# Altium schematic sheet 05_POWER.SchDoc(05_POWER)
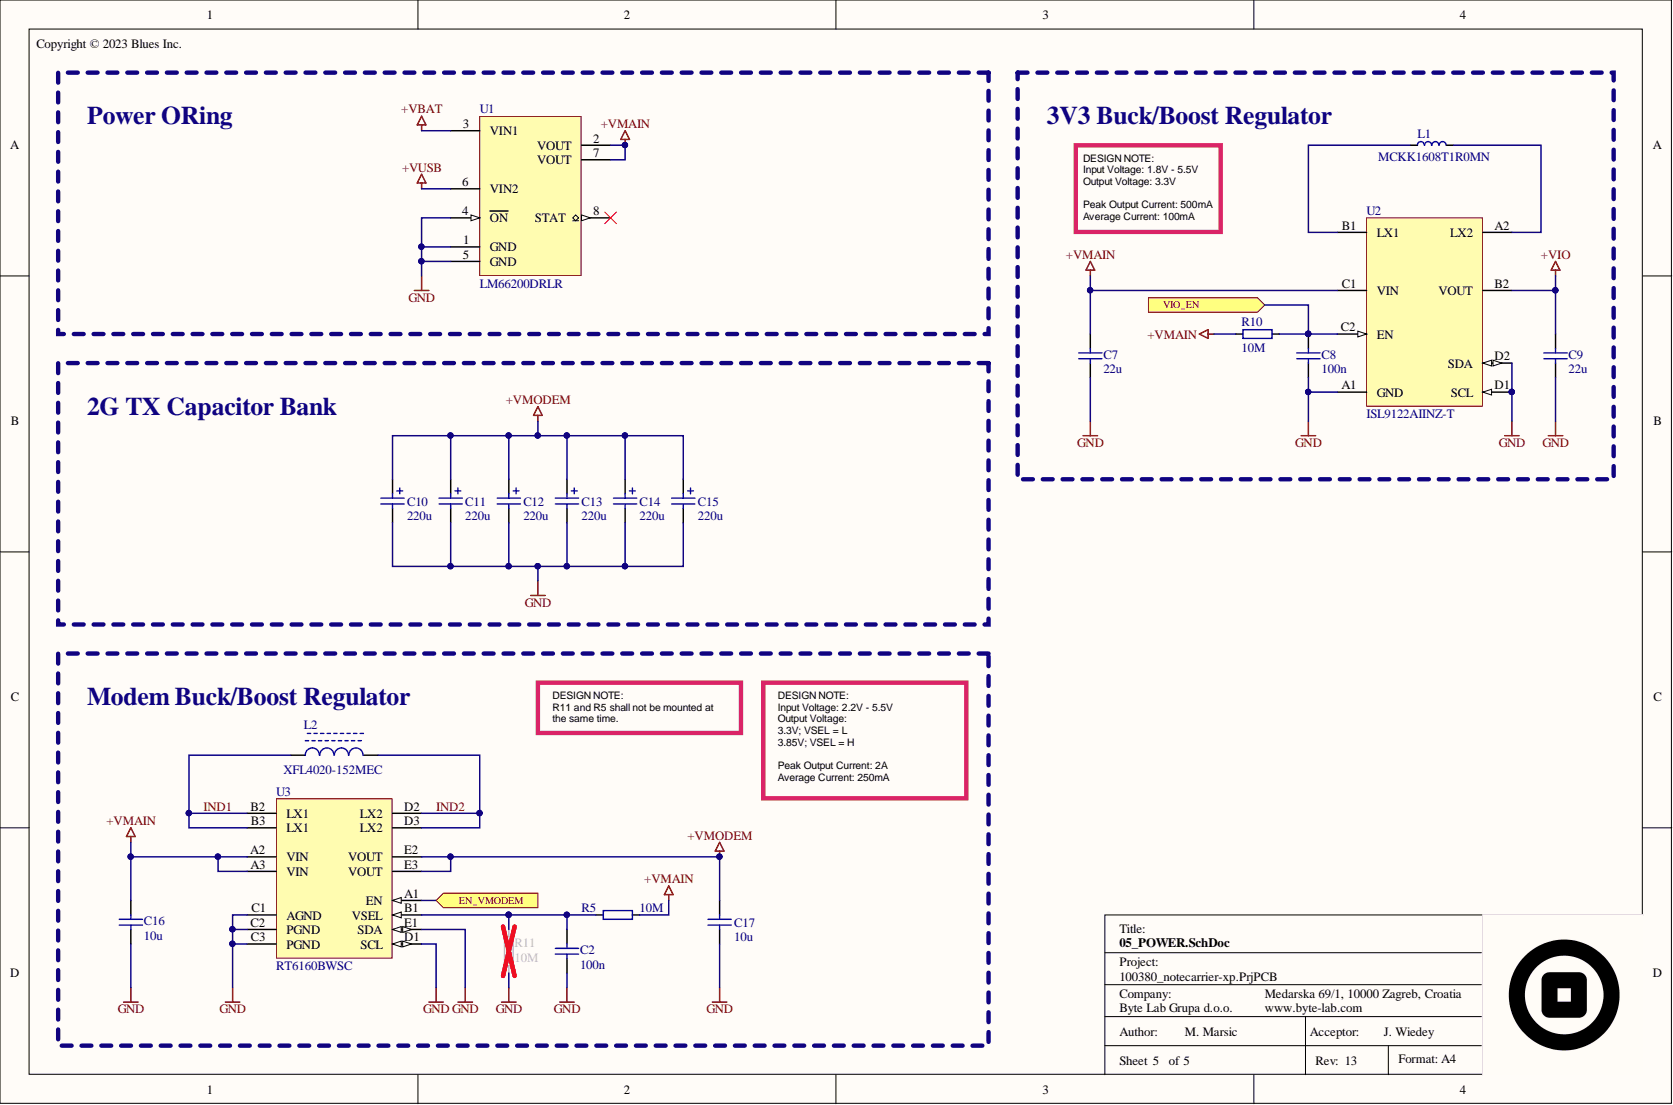
[diagram p0: 05_POWER.SchDoc]

## Parts
C2  100n  [C-0402]
C7  22u  [C-0805]
C8  100n  [C-0402]
C9  22u  [C-0805]
C10  220u  [CAPMP3528X21]
C11  220u  [CAPMP3528X21]
C12  220u  [CAPMP3528X21]
C13  220u  [CAPMP3528X21]
C14  220u  [CAPMP3528X21]
C15  220u  [CAPMP3528X21]
C16  10u  [C-0603]
C17  10u  [C-0603]
L1  MCKK1608T1R0MN  [L-0603]
L2  XFL4020-152MEC  [IND_XFL4020]
R5  10M  [R-0402]
R10  10M  [R-0402]
R11  10M  [R-0402]
U1  LM66200DRLR  [SOT-583]
U2  ISL9122AIINZ-T  [BGA8N40P2X4_180x100x50]
U3  RT6160BWSC  [BGA15N40P3X5_230x140x60]

## Ports
EN_VMODEM, VIO_EN

## Nets
+VBAT: U1.3(VIN1)
+VIO: C9.2 U2.B2(VOUT)
+VMAIN: C7.2 C16.2 R5.1 R10.1 U1.2(VOUT) U1.7(VOUT) U2.C1(VIN) U3.A2(VIN) U3.A3(VIN)
+VMODEM: C10.1 C11.1 C12.1 C13.1 C14.1 C15.1 C17.2 U3.E2(VOUT) U3.E3(VOUT)
+VUSB: U1.6(VIN2)
GND: C2.1 C7.1 C8.1 C9.1 C10.2 C11.2 C12.2 C13.2 C14.2 C15.2 C16.1 C17.1 R11.1 U1.1(GND) U1.4(ON) U1.5(GND) U2.A1(GND) U2.D1(SCL) U2.D2(SDA) U3.C1(AGND) U3.C2(PGND) U3.C3(PGND) U3.D1(SCL) U3.E1(SDA)
EN_VMODEM: U3.A1(EN) ; port EN_VMODEM
IND1: L2.1 U3.B2(LX1) U3.B3(LX1)
IND2: L2.2 U3.D2(LX2) U3.D3(LX2)
VIO_EN: C8.2 R10.2 U2.C2(EN) ; port VIO_EN
NetC2_2: C2.2 R5.2 R11.2 U3.B1(VSEL)
NetL1_1: L1.1 U2.B1(LX1)
NetL1_2: L1.2 U2.A2(LX2)

Not connected: U1 8(STAT)

## Library data of the parts
C2 C8 = C0402-100n-16V-X7R: Capacitor ceramic 100n ±10% X7R 16V 0402; BL Part Number: 2000-908; Checked_By: Dodig; Drawn_By: Puskar; Component Kind: Standard; DateModified: 27-08-2013; Library Name: BL_Passive.SchLib; Manufacturer: N/A; Manufacturer Part Number: N/A; Simulation: CAP; Supplier 1: Digi-Key; Supplier Part Number 1: 490-3261-1-ND
C7 C9 = C0805-22u-16V-X5R: Capacitor ceramic 22u ±10% X5R 16V 0805; BL Part Number: 2006-222; Checked_By: Hamin; Drawn_By: Fumic; Component Kind: Standard; DateModified: 28-07-2022; Library Name: BL_Passive.SchLib; Manufacturer: N/A; Manufacturer Part Number: N/A; Simulation: CAP
C10-C15 = CTant-B-220u-6V3: Capacitor tantalum 220u ±10% 6V3 B case; BL Part Number: 2006-854; Manufacturer: AVX / Kyocera; Manufacturer Part Number: F930J227KBA; Checked_By: Hlupic; Drawn_By: Marsic; Component Kind: Standard; DateModified: 13-10-2022; Library Name: BL_Passive.SchLib
C16 C17 = C0603-10u-6V3-X5R: Capacitor ceramic 10u ±20% X5R 6.3V 0603; BL Part Number: 2002-072; Checked_By: Dodig; Drawn_By: Puskar; Component Kind: Standard; DateModified: 19-01-2015; Library Name: BL_Passive.SchLib; Manufacturer: N/A; Manufacturer Part Number: N/A; Supplier 1: Digi-Key; Supplier Part Number 1: 1276-1119-1-ND
L1 = L0603-1u-20 MCKK1608T1R0MN: Inductor 0603 1u ±20% 1.3A; BL Part Number: 2003-989; Manufacturer: Taiyo Yuden; Checked_By: Hlupic; Drawn_By: Fumic; Component Kind: Standard; DateModified: 30-01-2020; Library Name: BL_Passive.SchLib; Manufacturer Part Number: MCKK1608T1R0MN; Supplier 1: Digi-Key; Supplier Part Number 1: 587-5973-1-ND
L2 = Inductor XFL4020-152MEC: Inductor 1u5 ±20% 4.6A SMD; BL Part Number: 2006-846; Manufacturer: Coilcraft; Checked_By: Hlupic; Drawn_By: Marsic; Component Kind: Standard; DateModified: 29-09-2022; Library Name: BL_Passive.SchLib; Manufacturer Part Number: XFL4020-152MEC
R5 R10 R11 = R0402-10M-1: Resistor 10M ±1% 0402; BL Part Number: 2002-832; Checked_By: Dodig; Drawn_By: Zvonc; Component Kind: Standard; DateModified: 24-05-2016; Library Name: BL_Passive.SchLib; Manufacturer: N/A; Manufacturer Part Number: N/A; Supplier 1: Digi-Key; Supplier Part Number 1: RC0402FR-0710ML
U1 = LM66200DRLR: IC, Power switch, OR Controller N+1 ORing Controller N-Channel 2:1 SOT-583; BL Part Number: 2007-318; Manufacturer: Texas Instruments; Checked_By: Hamin; Drawn_By: Golubic; Component Kind: Standard; DateModified: 06-02-2023; Library Name: BL_Analog.SchLib; Manufacturer Part Number: LM66200DRLR; Supplier 1: Digi-Key; Supplier Part Number 1: 296-LM66200DRLRTR-ND
U2 = ISL9122AIINZ-T: IC CON BUCK-BOOST ADJ 0.5A WLCSP-8; BL Part Number: 2007-147; Manufacturer: Renesas; Checked_By: Hlupic; Drawn_By: Marsic; Component Kind: Standard; DateModified: 13-01-2023; Library Name: BL_Analog.SchLib; Manufacturer Part Number: ISL9122AIINZ-T
U3 = RT6160BWSC: IC REG BUCK BOOST 2V025-5V2 3A; BL Part Number: 2008-326; Manufacturer: Richtek; Checked_By: Marsic; Drawn_By: Drago Anic-Ivicic; Component Kind: Standard; DateModified: 04-03-2024; Library Name: BL_Analog.SchLib; Manufacturer Part Number: RT6160BWSC; Supplier 1: Digi-Key
